# Altium schematic sheet Hallf_bridge.SchDoc
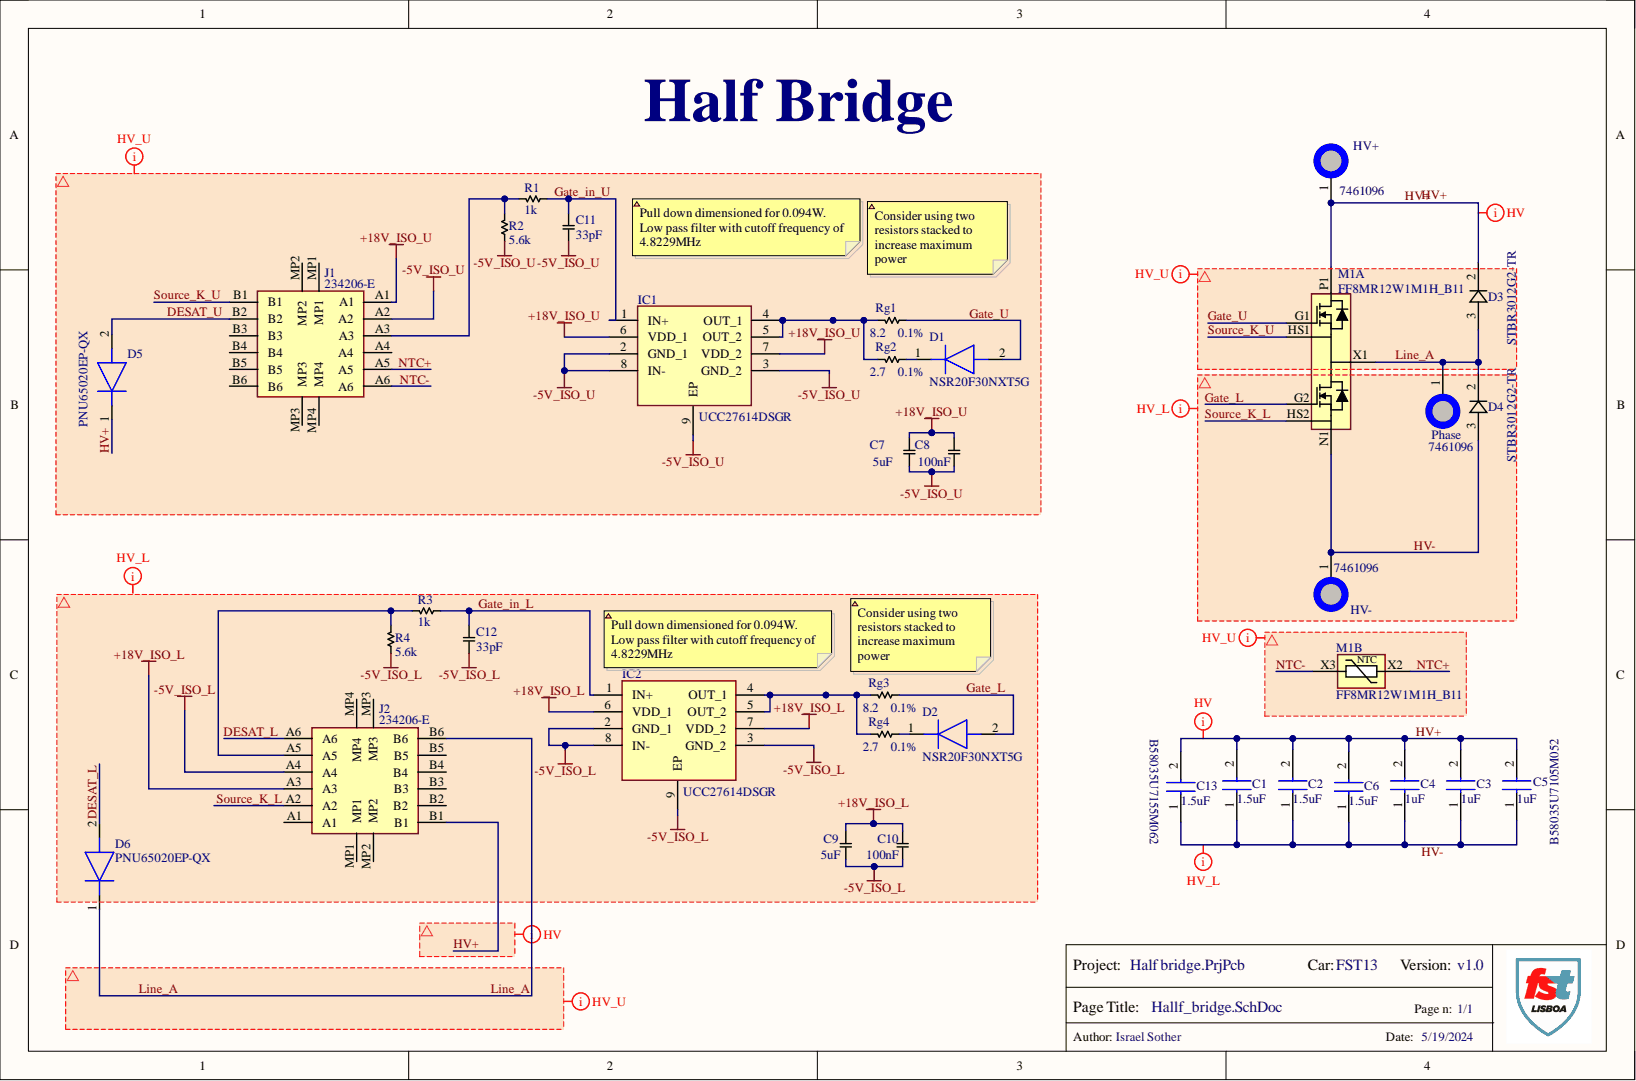
[diagram p0: Hallf_bridge.SchDoc]

## Parts
C1  B58035U7155M062  Capacitance: 1.5uF  [B58035U7155M062]
C2  B58035U7155M062  Capacitance: 1.5uF  [B58035U7155M062]
C3  B58035U7105M052  Capacitance: 1uF  [B58035U7105M052]
C4  B58035U7105M052  Capacitance: 1uF  [B58035U7105M052]
C5  B58035U7105M052  Capacitance: 1uF  [B58035U7105M052]
C6  B58035U7155M062  Capacitance: 1.5uF  [B58035U7155M062]
C7  Capacitance: 5uF  [CAPC2012N [0805]]  (hidden comment: Capacitor)
C8  Capacitance: 100nF  [CAPC1608N [0603]]  (hidden comment: Capacitor)
C9  Capacitance: 5uF  [CAPC2012N [0805]]  (hidden comment: Capacitor)
C10  Capacitance: 100nF  [CAPC1608N [0603]]  (hidden comment: Capacitor)
C11  Capacitance: 33pF  [CAPC1608N [0603]]  (hidden comment: Capacitor)
C12  Capacitance: 33pF  [CAPC1608N [0603]]  (hidden comment: Capacitor)
C13  B58035U7155M062  Capacitance: 1.5uF  [B58035U7155M062]
D1  NSR20F30NXT5G  [DSN2_1]
D2  NSR20F30NXT5G  [DSN2_1]
D3  (no value)  [STBR3012G2TR modified]
D4  (no value)  [STBR3012G2TR modified]
D5  PNU65020EP-QX  [PNU65020EPQX]
D6  PNU65020EP-QX  [PNU65020EPQX]
HV+  7461096  [7461096]
HV-  7461096  [7461096]
IC1  UCC27614DSGR  [SON50P200X200X80-9N]
IC2  UCC27614DSGR  [SON50P200X200X80-9N]
J1  234206-E  [234206-E]
J2  234206-E  [234206-E]
M1  FF8MR12W1M1H_B11  [FF8MR12W1M1H_B11 - no cooling attachment holes]  (parts A,B)
Phase  7461096  [7461096]
R1  Resistance: 1k  [RESC1608N [0603]]
R2  Resistance: 5.6k  [RESC1608N [0603]]
R3  Resistance: 1k  [RESC1608N [0603]]
R4  Resistance: 5.6k  [RESC1608N [0603]]
Rg1  Tolerance: 0.1%  [RESC1608N [0603]]  (hidden comment: Resistor)
Rg2  Resistance: 2.7  Tolerance: 0.1%  [RESC1608N [0603]]  (hidden comment: Resistor)
Rg3  Resistance: 8.2  Tolerance: 0.1%  [RESC1608N [0603]]  (hidden comment: Resistor)
Rg4  Resistance: 2.7  Tolerance: 0.1%  [RESC1608N [0603]]  (hidden comment: Resistor)

## Nets
+18V_ISO_L: C9.2 C10.2 IC2.6(VDD_1) IC2.7(VDD_2) J2.A3
+18V_ISO_U: C7.2 C8.2 IC1.6(VDD_1) IC1.7(VDD_2) J1.A1
-5V_ISO_L: C9.1 C10.1 C12.1 IC2.2(GND_1) IC2.3(GND_2) IC2.8(IN-) IC2.9(EP) J2.A4 R4.1
-5V_ISO_U: C7.1 C8.1 C11.1 IC1.2(GND_1) IC1.3(GND_2) IC1.8(IN-) IC1.9(EP) J1.A2 R2.1
DESAT_L: D6.2 J2.A6
DESAT_U: D5.2 J1.B2
Gate_in_L: C12.2 IC2.1(IN+) R3.1
Gate_in_U: C11.2 IC1.1(IN+) R1.1
Gate_L: D2.2 M1.G2 Rg3.1
Gate_U: D1.2 M1.G1 Rg1.1
HV+: C1.2 C2.2 C3.2 C4.2 C5.2 C6.2 C13.2 D3.2 D5.1 HV+.1 J2.B1 M1.P1
HV-: C1.1 C2.1 C3.1 C4.1 C5.1 C6.1 C13.1 D4.3 HV-.1 M1.N1
Line_A: D3.3 D4.2 D6.1 J2.B6 M1.X1 Phase.1
NTC+: J1.A5 M1.X2
NTC-: J1.A6 M1.X3
Source_K_L: J2.A2 M1.HS2
Source_K_U: J1.B1 M1.HS1
NetD1_1: D1.1 Rg2.1
NetD2_1: D2.1 Rg4.1
NetIC1_4: IC1.4(OUT_1) IC1.5(OUT_2) Rg1.2 Rg2.2
NetIC2_4: IC2.4(OUT_1) IC2.5(OUT_2) Rg3.2 Rg4.2
NetJ1_A3: J1.A3 R1.2 R2.2
NetJ2_A5: J2.A5 R3.2 R4.2

Not connected: J1 A4 B3 B4 B5 B6 MP1 MP2 MP3 MP4; J2 A1 B2 B3 B4 B5 MP1 MP2 MP3 MP4

## Library data of the parts
C1 C2 C6 C13 = B58035U7155M062: Capacitor; Manufacturer_Name: TDK; Component Kind: Standard; Datasheet Link: https://product.tdk.com/system/files/dam/doc/product/capacitor/ceramic/ceralink/data_sheet/20/10/ds/b58035_fa.pdf; Height: 9.6mm; Manufacturer_Part_Number: B58035U7155M062; Mouser Part Number: 871-B58035U7155M062; Mouser Price/Stock: https://www.mouser.co.uk/ProductDetail/EPCOS-TDK/B58035U7155M062?qs=byeeYqUIh0MjaAmv%252BeXOAw%3D%3D
C3-C5 = B58035U7105M052: Capacitor; Manufacturer_Name: TDK; Arrow Part Number: B58035U7105M052; Arrow Price/Stock: https://www.arrow.com/en/products/b58035u7105m052/epcos-tdk; Component Kind: Standard; Datasheet Link: https://product.tdk.com/system/files/dam/doc/product/capacitor/ceramic/ceralink/data_sheet/20/10/ds/b58035_fa.pdf; Height: 9.6mm; Manufacturer_Part_Number: B58035U7105M052; Mouser Part Number: 871-B58035U7105M052; Mouser Price/Stock: https://www.mouser.co.uk/ProductDetail/EPCOS-TDK/B58035U7105M052?qs=EBDBlbfErPw0QIErVEGx%252Bw%3D%3D
D1 D2 = NSR20F30NXT5G: Diode; Manufacturer_Name: onsemi; Arrow Part Number: NSR20F30NXT5G; Arrow Price/Stock: https://www.arrow.com/en/products/nsr20f30nxt5g/on-semiconductor?region=nac; Component Kind: Standard; Datasheet Link: http://www.onsemi.com/pub/Collateral/NSR20F30-D.PDF; Manufacturer_Part_Number: NSR20F30NXT5G; Mouser Part Number: 863-NSR20F30NXT5G; Mouser Price/Stock: https://www.mouser.co.uk/ProductDetail/onsemi/NSR20F30NXT5G?qs=3ezCrKCRT5K%2FARb%252BJ8n8Hg%3D%3D
D3 D4 = STBR3012G2-TR: Integrated Circuit; Manufacturer_Name: STMicroelectronics; Arrow Part Number: STBR3012G2-TR; Arrow Price/Stock: https://www.arrow.com/en/products/stbr3012g2-tr/stmicroelectronics; Component Kind: Standard; Datasheet Link: https://www.st.com/resource/en/datasheet/stbr3012.pdf; Height: 4.9mm; Manufacturer_Part_Number: STBR3012G2-TR; Mouser Part Number: 511-STBR3012G2-TR; Mouser Price/Stock: https://www.mouser.co.uk/ProductDetail/STMicroelectronics/STBR3012G2-TR?qs=MLItCLRbWszOCQaNEO%2F4yQ%3D%3D; Library Name: Half bridge.IntLib
D5 D6 = PNU65020EP-QX: Diode; Manufacturer_Name: Nexperia; Component Kind: Standard; Datasheet Link: https://assets.nexperia.com/documents/data-sheet/PNU65020EP-Q.pdf; Height: 1.1mm; Manufacturer_Part_Number: PNU65020EP-QX
HV+ HV- Phase = 7461096: Connector; Manufacturer_Name: Wurth Elektronik; Component Kind: Standard; Datasheet Link: http://katalog.we-online.com/em/datasheet/7461096.pdf; Manufacturer_Part_Number: 7461096; Mouser Part Number: 710-7461096; Mouser Price/Stock: https://www.mouser.co.uk/ProductDetail/Wurth-Elektronik/7461096?qs=lykWx4dhCCGg3D9mff2MJA%3D%3D; System: Connector
  HV-: Library Name: Half bridge.SCHLIB
  HV+ Phase: Library Name: Half bridge.IntLib
IC1 IC2 = UCC27614DSGR: Integrated Circuit; Manufacturer_Name: Texas Instruments; Arrow Part Number: UCC27614DSGR; Arrow Price/Stock: https://www.arrow.com/en/products/ucc27614dsgr/texas-instruments?region=nac; Component Kind: Standard; Datasheet Link: https://www.ti.com/lit/ds/symlink/ucc27614.pdf?ts=1636432512065&ref_url=https%253A%252F%252Fwww.ti.com%252Fpower-management%252Fgate-drivers%252Flow-side-drivers%252Fproducts.html; Height: 0.8mm; Library Name: SamacSys.SchLib; Manufacturer_Part_Number: UCC27614DSGR; Mouser Part Number: 595-UCC27614DSGR; Mouser Price/Stock: https://www.mouser.co.uk/ProductDetail/Texas-Instruments/UCC27614DSGR?qs=Rp5uXu7WBW8uETRWNvsy1Q%3D%3D
J1 J2 = 234206-E: Connector; Manufacturer_Name: TE Connectivity; Manufacturer_Part_Number: 234198-E; Component Kind: Standard; Datasheet Link: https://www.te.com/commerce/DocumentDelivery/DDEController?Action=srchrtrv&DocNm=erni-smc-1.27mm-connectors-ds&DocType=Data%20Sheet&DocLang=German&PartCntxt=234198-E&DocFormat=pdf; Height: 13.95mm; Library Name: SamacSys.SchLib; System: Connector
M1 = FF8MR12W1M1H_B11: Infineon SiC Mosfet Half Bridge Module; Component Kind: Standard; Datasheet: https://www.infineon.com/dgdl/Infineon-FF8MR12W1M1H_B11-DataSheet-v00_30-EN.pdf?fileId=8ac78c8c8b6555fe018b72791dec7c06; Library Name: Half bridge.SCHLIB
R1-R4 = Resistor: Component Kind: Standard; Description: Resistor; Library Name: FSTlib.SchLib
Rg1 = Resistor: Resistance: 8.2; Component Kind: Standard; Description: Resistor; Library Name: InverterSchematicLib.SchLib
C7-C12 = Capacitor: Component Kind: Standard; Description: Capacitor; Library Name: InverterSchematicLib.SchLib
Rg2-Rg4 = Resistor: Component Kind: Standard; Description: Resistor; Library Name: InverterSchematicLib.SchLib
